# Altium schematic sheet 3. ISO1211.SchDoc("3. ISO1211")
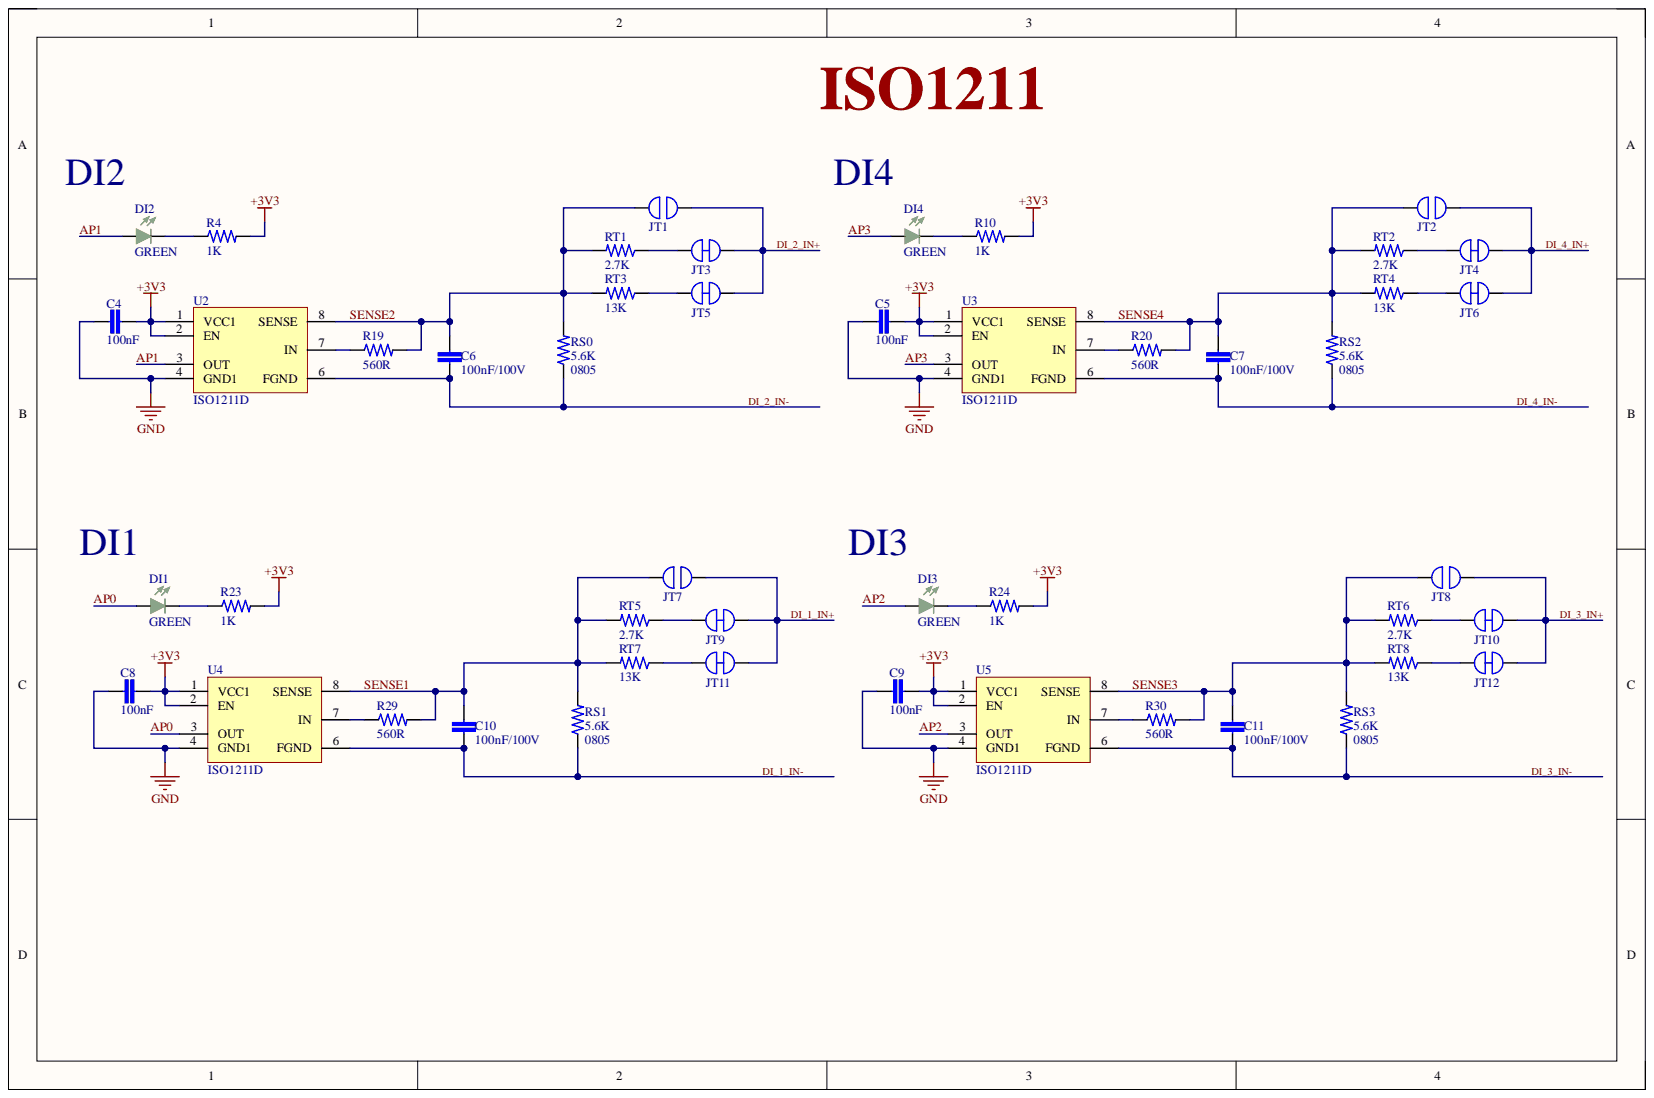
[diagram p0: 3. ISO1211.SchDoc]

## Parts
C4  100nF  [CAPC1005X55N-0402]
C5  100nF  [CAPC1005X55N-0402]
C6  100nF/100V  [CAP0603]
C7  100nF/100V  [CAP0603]
C8  100nF  [CAPC1005X55N-0402]
C9  100nF  [CAPC1005X55N-0402]
C10  100nF/100V  [CAP0603]
C11  100nF/100V  [CAP0603]
DI1  GREEN  [LED0603_GREEN]
DI2  GREEN  [LED0603_GREEN]
DI3  GREEN  [LED0603_GREEN]
DI4  GREEN  [LED0603_GREEN]
JT1  SOLDER_JUMPER_NO_2W  [SOLDER_JUMPER_NO_2W]  (value not drawn)
JT2  SOLDER_JUMPER_NO_2W  [SOLDER_JUMPER_NO_2W]  (value not drawn)
JT3  SOLDER_JUMPER_NC_2W  [SOLDER_JUMPER_NC_2W]  (value not drawn)
JT4  SOLDER_JUMPER_NC_2W  [SOLDER_JUMPER_NC_2W]  (value not drawn)
JT5  SOLDER_JUMPER_NC_2W  [SOLDER_JUMPER_NC_2W]  (value not drawn)
JT6  SOLDER_JUMPER_NC_2W  [SOLDER_JUMPER_NC_2W]  (value not drawn)
JT7  SOLDER_JUMPER_NO_2W  [SOLDER_JUMPER_NO_2W]  (value not drawn)
JT8  SOLDER_JUMPER_NO_2W  [SOLDER_JUMPER_NO_2W]  (value not drawn)
JT9  SOLDER_JUMPER_NC_2W  [SOLDER_JUMPER_NC_2W]  (value not drawn)
JT10  SOLDER_JUMPER_NC_2W  [SOLDER_JUMPER_NC_2W]  (value not drawn)
JT11  SOLDER_JUMPER_NC_2W  [SOLDER_JUMPER_NC_2W]  (value not drawn)
JT12  SOLDER_JUMPER_NC_2W  [SOLDER_JUMPER_NC_2W]  (value not drawn)
R4  1K  [RESC1608X04N]
R10  1K  [RESC1608X04N]
R19  560R  [RESC1608X04N]
R20  560R  [RESC1608X04N]
R23  1K  [RESC1608X04N]
R24  1K  [RESC1608X04N]
R29  560R  [RESC1608X04N]
R30  560R  [RESC1608X04N]
RS0  5.6K  [0805]
RS1  5.6K  [0805]
RS2  5.6K  [0805]
RS3  5.6K  [0805]
RT1  2.7K  [RESC-0603 (1608) - HD]
RT2  2.7K  [RESC-0603 (1608) - HD]
RT3  13K  [RESC-0603 (1608) - HD]
RT4  13K  [RESC-0603 (1608) - HD]
RT5  2.7K  [RESC-0603 (1608) - HD]
RT6  2.7K  [RESC-0603 (1608) - HD]
RT7  13K  [RESC-0603 (1608) - HD]
RT8  13K  [RESC-0603 (1608) - HD]
U2  ISO1211D  [SOIC127P600X175-8N]
U3  ISO1211D  [SOIC127P600X175-8N]
U4  ISO1211D  [SOIC127P600X175-8N]
U5  ISO1211D  [SOIC127P600X175-8N]

## Nets
+3V3: C4.2 C5.2 C8.2 C9.2 R4.2 R10.2 R23.2 R24.2 U2.1 U2.2 U3.1 U3.2 U4.1 U4.2 U5.1 U5.2
GND: C4.1 C5.1 C8.1 C9.1 U2.4 U3.4 U4.4 U5.4
AP0: DI1.A U4.3
AP1: DI2.A U2.3
AP2: DI3.A U5.3
AP3: DI4.A U3.3
DI_1_IN+: JT7.1 JT9.1 JT11.1
DI_1_IN-: C10.2 RS1.2 U4.6
DI_2_IN+: JT1.1 JT3.1 JT5.1
DI_2_IN-: C6.2 RS0.2 U2.6
DI_3_IN+: JT8.1 JT10.1 JT12.1
DI_3_IN-: C11.2 RS3.2 U5.6
DI_4_IN+: JT2.1 JT4.1 JT6.1
DI_4_IN-: C7.2 RS2.2 U3.6
SENSE1: C10.1 JT7.2 R29.2 RS1.1 RT5.1 RT7.1 U4.8
SENSE2: C6.1 JT1.2 R19.2 RS0.1 RT1.1 RT3.1 U2.8
SENSE3: C11.1 JT8.2 R30.2 RS3.1 RT6.1 RT8.1 U5.8
SENSE4: C7.1 JT2.2 R20.2 RS2.1 RT2.1 RT4.1 U3.8
NetDI1_K: DI1.K R23.1
NetDI2_K: DI2.K R4.1
NetDI3_K: DI3.K R24.1
NetDI4_K: DI4.K R10.1
NetJT3_2: JT3.2 RT1.2
NetJT4_2: JT4.2 RT2.2
NetJT5_2: JT5.2 RT3.2
NetJT6_2: JT6.2 RT4.2
NetJT9_2: JT9.2 RT5.2
NetJT10_2: JT10.2 RT6.2
NetJT11_2: JT11.2 RT7.2
NetJT12_2: JT12.2 RT8.2
NetR19_1: R19.1 U2.7
NetR20_1: R20.1 U3.7
NetR29_1: R29.1 U4.7
NetR30_1: R30.1 U5.7

## Library data of the parts
C4 C5 C8 C9 = CAP0402_100nF: CAP CER 0.1UF 50V X5R 0402; Manufacturer: Murata; Manufacturer Part Number: GRM155R61H104KE19D; Tolerance: 10%; Capacitance: 100nF; Case Code (Imperial): 0402; Case Code (Metric): 1005; Case/Package: 0402; Category: Ceramic Capacitors; Component Kind: Standard; ComponentLink1Description: https://datasheet.ciiva.com/22698/1666664-22698413.pdf?src-supplier=Element14; ComponentLink1URL: https://datasheet.ciiva.com/22698/1666664-22698413.pdf?src-supplier=Element14; ComponentLink2Description: https://datasheet.ciiva.com/7719/1666664-7719007.pdf?src-supplier=Element14; ComponentLink2URL: https://datasheet.ciiva.com/7719/1666664-7719007.pdf?src-supplier=Element14; Depth: 0.5mm; Dielectric: X5R; Height: 0.5mm; Lead Free: Lead Free; Length: 1mm; Library Name: Schematic_Lib.SchLib; Max Operating Temperature: 85°C; Min Operating Temperature: -55°C; Mount: Surface Mount; Packaging: Tape and Reel; Pricing 1: 1=0.11000, 10=0.08300, 50=0.04560, 100=0.03720, 500=0.02656, 1000=0.02087, 2500=0.01898, 5000=0.01746 (USD); REACH SVHC: No SVHC; RoHS: Yes; Stock 1: 1119342; Supplier 1: Digi-Key; Supplier Part Number 1: 490-11917-1-ND; Thickness: 0.022inch; Voltage: 50V; Voltage Rating: 50V; Voltage Rating (DC): 50V; Width: 0.02inch
C6 C7 C10 C11 = CAP0603_100nF_100V: CAP CER 0.1UF 100V X7R 0603; Manufacturer: Murata; Manufacturer Part Number: GRM188R72A104KA35D; Capacitance: 100nF; Material: Ceramic; Tolerance: 10%; Case Code (Imperial): 0603; Case Code (Metric): 1608; Case/Package: 0603; Category: Ceramic Capacitors; Component Kind: Standard; ComponentLink1Description: https://datasheet.ciiva.com/22703/1747473-22703345.pdf?src-supplier=Element14; ComponentLink1URL: https://datasheet.ciiva.com/22703/1747473-22703345.pdf?src-supplier=Element14; ComponentLink2Description: https://datasheet.ciiva.com/18100/getdatasheetpartid-27818-18100710.pdf?src-supplier=Verical; ComponentLink2URL: https://datasheet.ciiva.com/18100/getdatasheetpartid-27818-18100710.pdf?src-supplier=Verical; ComponentLink3Description: https://datasheet.ciiva.com/11787/0900766b80ef921d-11787064.pdf?src-supplier=RSComponents; ComponentLink3URL: https://datasheet.ciiva.com/11787/0900766b80ef921d-11787064.pdf?src-supplier=RSComponents; ComponentLink4Description: https://datasheet.ciiva.com/34302/1857815-34302863.pdf?src-supplier=Jameco; ComponentLink4URL: https://datasheet.ciiva.com/34302/1857815-34302863.pdf?src-supplier=Jameco; ComponentLink5Description: https://datasheet.ciiva.com/29201/451984-da-01-en-vs-kondensator-0603-29201725.pdf?src-supplier=Conrad; ComponentLink5URL: https://datasheet.ciiva.com/29201/451984-da-01-en-vs-kondensator-0603-29201725.pdf?src-supplier=Conrad; ComponentLink6Description: https://datasheet.ciiva.com/135/partnumbering-e-01-135139.pdf?src-supplier=Digi-Key; ComponentLink6URL: https://datasheet.ciiva.com/135/partnumbering-e-01-135139.pdf?src-supplier=Digi-Key; ComponentLink7Description: https://datasheet.ciiva.com/135/partnumbering-e-01-135140.pdf?src-supplier=Digi-Key; ComponentLink7URL: https://datasheet.ciiva.com/135/partnumbering-e-01-135140.pdf?src-supplier=Digi-Key; ComponentLink8Description: https://datasheet.ciiva.com/135/partnumbering-e-01-135141.pdf?src-supplier=Digi-Key; ComponentLink8URL: https://datasheet.ciiva.com/135/partnumbering-e-01-135141.pdf?src-supplier=Digi-Key; ComponentLink9Description: https://datasheet.ciiva.com/16382/product-16382965.pdf?src-supplier=Future+Electronics; ComponentLink9URL: https://datasheet.ciiva.com/16382/product-16382965.pdf?src-supplier=Future+Electronics; ComponentLink10Description: https://datasheet.ciiva.com/7937/1747473-7937676.pdf?src-supplier=Element14; ComponentLink10URL: https://datasheet.ciiva.com/7937/1747473-7937676.pdf?src-supplier=Element14; Construction: Flat; Depth: 0.8mm; Dielectric: X7R; Height: 0.8mm; Lead Free: Lead Free; Length: 1.6mm; Library Name: Schematic_Lib.SchLib; Max Operating Temperature: 125°C; Min Operating Temperature: -55°C; Mount: Surface Mount; Package Quantity: 1; Packaging: Tape and Reel; Pricing 1: 1=0.28000, 10=0.19200, 50=0.11540, 100=0.09740, 500=0.06666, 1000=0.05897 (USD); Radiation Hardening: No; REACH SVHC: No SVHC; RoHS: Yes; RoHS Compliant: Yes; Series: GRM; Size: 0.8mm; Stock 1: 2193; Supplier 1: Digi-Key; Supplier Part Number 1: 490-3285-1-ND; Termination: SMD/SMT; Thickness: 0.035inch; Voltage: 100V; Voltage Rating: 100V; Voltage Rating (DC): 100V; Weight: 0.000222oz; Width: 0.8mm
DI1-DI4 = LED0603_GREEN: LED GREEN CLEAR SMD; Manufacturer: Vishay Lite-On; Manufacturer Part Number: LTST-C191KGKT; Dominant Wavelength: 571nm; Forward Current: 30mA; Forward Voltage: 2V; Lens Color: Colorless; Lens Style: Rectangular,Colorless; Luminous Intensity: 35mcd; Max Power Dissipation: 75mW; Number of Elements: 1; Reverse Voltage: 5V; Test Current: 20mA; Viewing Angle: 130°; Wavelength: 574nm; Case Code (Imperial): 0603; Case Code (Metric): 1608; Case/Package: 0603; Category: LEDs; Color: Green; Component Kind: Standard; ComponentLink1Description: https://datasheet.ciiva.com/2109/ltst-c191kgkt-2109973.pdf?src-supplier=Digi-Key; ComponentLink1URL: https://datasheet.ciiva.com/2109/ltst-c191kgkt-2109973.pdf?src-supplier=Digi-Key; Depth: 0.8mm; Height: 0.55mm; Illumination Color: Green; Lead Free: Lead Free; Length: 1.6mm; Lens Transparency: Clear; Library Name: Schematic_Lib.SchLib; Max Operating Temperature: 85°C; Min Operating Temperature: -55°C; Mount: Surface Mount; Number of LEDs: 1; Number of Pins: 2; Package Quantity: 1; Packaging: Tape and Reel; Power Dissipation: 75mW; Power Rating: 75mW; Pricing 1: 1=0.26000, 10=0.14100, 100=0.06560, 500=0.06060, 1000=0.04747, 2000=0.04646 (USD); Radiation Hardening: No; RoHS: Yes; RoHS Compliant: Yes; Stock 1: 910352; Supplier 1: Digi-Key; Supplier Part Number 1: 160-1446-1-ND; Width: 0.8mm
JT1 JT2 JT7 JT8 = SOLDER_JUMPER_NO_2W: Solder Jumper; Component Kind: Net Tie; Library Name: Bokra.SCHLIB
JT3-JT6 JT9-JT12 = SOLDER_JUMPER_NC_2W: Solder Jumper; Component Kind: Net Tie; Library Name: Bokra.SCHLIB
R4 R10 R23 R24 = RES0603_1K: RES 1K OHM 1% 1/10W 0603; Manufacturer: Yageo; Manufacturer Part Number: RC0603FR-071KL; Max Power Dissipation: 100mW; Resistance: 1kR; Temperature Coefficient: 100ppm/°C; Voltage Rating: 50V; Case Code (Imperial): 0603; Case Code (Metric): 1608; Case/Package: 0603; Category: Chip SMD Resistors; Component Kind: Standard; Construction: Rectangular; Contact Plating: Tin,Matte; Depth: 0.8mm; Features: Moisture Resistant; Height: 0.45mm; Lead Free: Lead Free; Length: 1.6mm; Library Name: Schematic_Lib.SchLib; Max Operating Temperature: 155°C; Military Standard: Not; Min Operating Temperature: -55°C; Number of Terminations: 2; Packaging: Tape and Reel; Power Rating: 100mW; Pricing 1: 1=0.10000, 10=0.02400, 100=0.00970, 1000=0.00436, 2500=0.00378 (USD); Radiation Hardening: No; REACH SVHC: No SVHC; Resistance Tolerance: 1%; Resistor Type: Thick Film; RoHS: Yes; RoHS Compliant: Yes; Series: RC; Size Code: 0603; Stock 1: 5743905; Supplier 1: Digi-Key; Supplier Part Number 1: 311-1.00KHRCT-ND; Technology: THICK FILM; Termination: SMD/SMT; Tolerance: 1%
R19 R20 R29 R30 = RES0603_560R: RES 560 OHM 1% 1/10W 0603; Manufacturer: Yageo; Manufacturer Part Number: RC0603FR-07560RL; Max Power Dissipation: 100mW; Temperature Coefficient: 100ppm/°C; Voltage Rating: 75V; Voltage Rating (DC): 50V; Case Code (Imperial): 0603; Case Code (Metric): 1608; Case/Package: 0603; Category: Chip SMD Resistors; Component Kind: Standard; ComponentLink1Description: https://datasheet.ciiva.com/11808/0900766b80f96399-11808127.pdf?src-supplier=RSComponents; ComponentLink1URL: https://datasheet.ciiva.com/11808/0900766b80f96399-11808127.pdf?src-supplier=RSComponents; ComponentLink2Description: https://datasheet.ciiva.com/46412/443971-46412853.pdf?src-supplier=Element14; ComponentLink2URL: https://datasheet.ciiva.com/46412/443971-46412853.pdf?src-supplier=Element14; ComponentLink3Description: https://datasheet.ciiva.com/7746/443971-7746374.pdf?src-supplier=Element14; ComponentLink3URL: https://datasheet.ciiva.com/7746/443971-7746374.pdf?src-supplier=Element14; ComponentLink4Description: https://datasheet.ciiva.com/7746/443971-7746375.pdf?src-supplier=Element14; ComponentLink4URL: https://datasheet.ciiva.com/7746/443971-7746375.pdf?src-supplier=Element14; ComponentLink5Description: https://datasheet.ciiva.com/2454/pyu-r-int-thick-7-2454381.pdf?src-supplier=Digi-Key; ComponentLink5URL: https://datasheet.ciiva.com/2454/pyu-r-int-thick-7-2454381.pdf?src-supplier=Digi-Key; ComponentLink6Description: https://datasheet.ciiva.com/2454/pyu-r-int-thick-7-2454382.pdf?src-supplier=Digi-Key; ComponentLink6URL: https://datasheet.ciiva.com/2454/pyu-r-int-thick-7-2454382.pdf?src-supplier=Digi-Key; ComponentLink7Description: https://datasheet.ciiva.com/2454/pyu-r-int-thick-7-2454383.pdf?src-supplier=Digi-Key; ComponentLink7URL: https://datasheet.ciiva.com/2454/pyu-r-int-thick-7-2454383.pdf?src-supplier=Digi-Key; Construction: Rectangular; Depth: 0.8mm; Features: Moisture Resistant; Height: 0.45mm; Lead Free: Lead Free; Length: 1.6mm; Library Name: Schematic_Lib.SchLib; Max Operating Temperature: 155°C; Military Standard: Not; Min Operating Temperature: -55°C; Number of Pins: 2; Number of Terminations: 2; Package Quantity: 5000; Packaging: Tape and Reel; Power Rating: 100mW; Pricing 1: 1=0.10000, 10=0.02400, 100=0.00970, 1000=0.00436, 2500=0.00378 (USD); REACH SVHC: No SVHC; Resistance: 560R; Resistance Tolerance: 1%; Resistor Type: Thick Film; RoHS: Yes; RoHS Compliant: Yes; Series: RC; Stock 1: 669399; Supplier 1: Digi-Key; Supplier Part Number 1: 311-560HRCT-ND; Technology: THICK FILM; Termination: SMD/SMT; Tolerance: 1%; Weight: 7.1E-05oz; Width: 0.8mm; Working Voltage: 75V
RS0-RS3 = R0805_5.6K: Solder: SMT; Component Kind: Standard; Description: R0805_5.6K; LCSC #: C4142405; Value: 5.6K; Library Name: Bokra.SCHLIB
RT1 RT2 RT5 RT6 = R0603_2.7K: MFR: Yageo; Category: RESISTOR; Component Kind: Standard; Description: R0603_2.7K; Solder: RES-0603; Value: 2.7K; Library Name: Bokra.SCHLIB
RT3 RT4 RT7 RT8 = R0603_13LK: MFR: Yageo; Category: RESISTOR; Component Kind: Standard; Description: R0603_13LK; Solder: RES-0603; Value: 13K; Library Name: Bokra.SCHLIB
U2-U5 = IC_ISO1211D: IC INTERFACE SPECIALIZED 8SOIC; Manufacturer: Texas Instruments; Number of Channels: 1; Case/Package: SOIC; Category: Interface ICs; Component Kind: Standard; ComponentLink1Description: http://www.ti.com/lit/ds/symlink/iso1212.pdf?src-supplier=Farnell; ComponentLink1URL: http://www.ti.com/lit/ds/symlink/iso1212.pdf?src-supplier=Farnell; ComponentLink2Description: http://www.ti.com/lit/ds/symlink/iso1211.pdf?src-supplier=Digi-Key; ComponentLink2URL: http://www.ti.com/lit/ds/symlink/iso1211.pdf?src-supplier=Digi-Key; Library Name: Schematic_Lib.SchLib; Manufacturer Part Number: ISO1211D; Max Operating Temperature: 125°C; Min Operating Temperature: -55°C; Mount: Surface Mount; Packaging: Rail/Tube; Pricing 1: 1=1.32000, 10=1.18200, 25=1.12200, 100=0.92160, 250=0.86148, 500=0.76130, 1000=0.66150 (USD); RoHS: Yes; RoHS Compliant: Yes; Supplier 1: Digi-Key; Supplier Part Number 1: 296-47452-ND
